# Altium schematic sheet MAX31856 Sensors 1.SchDoc
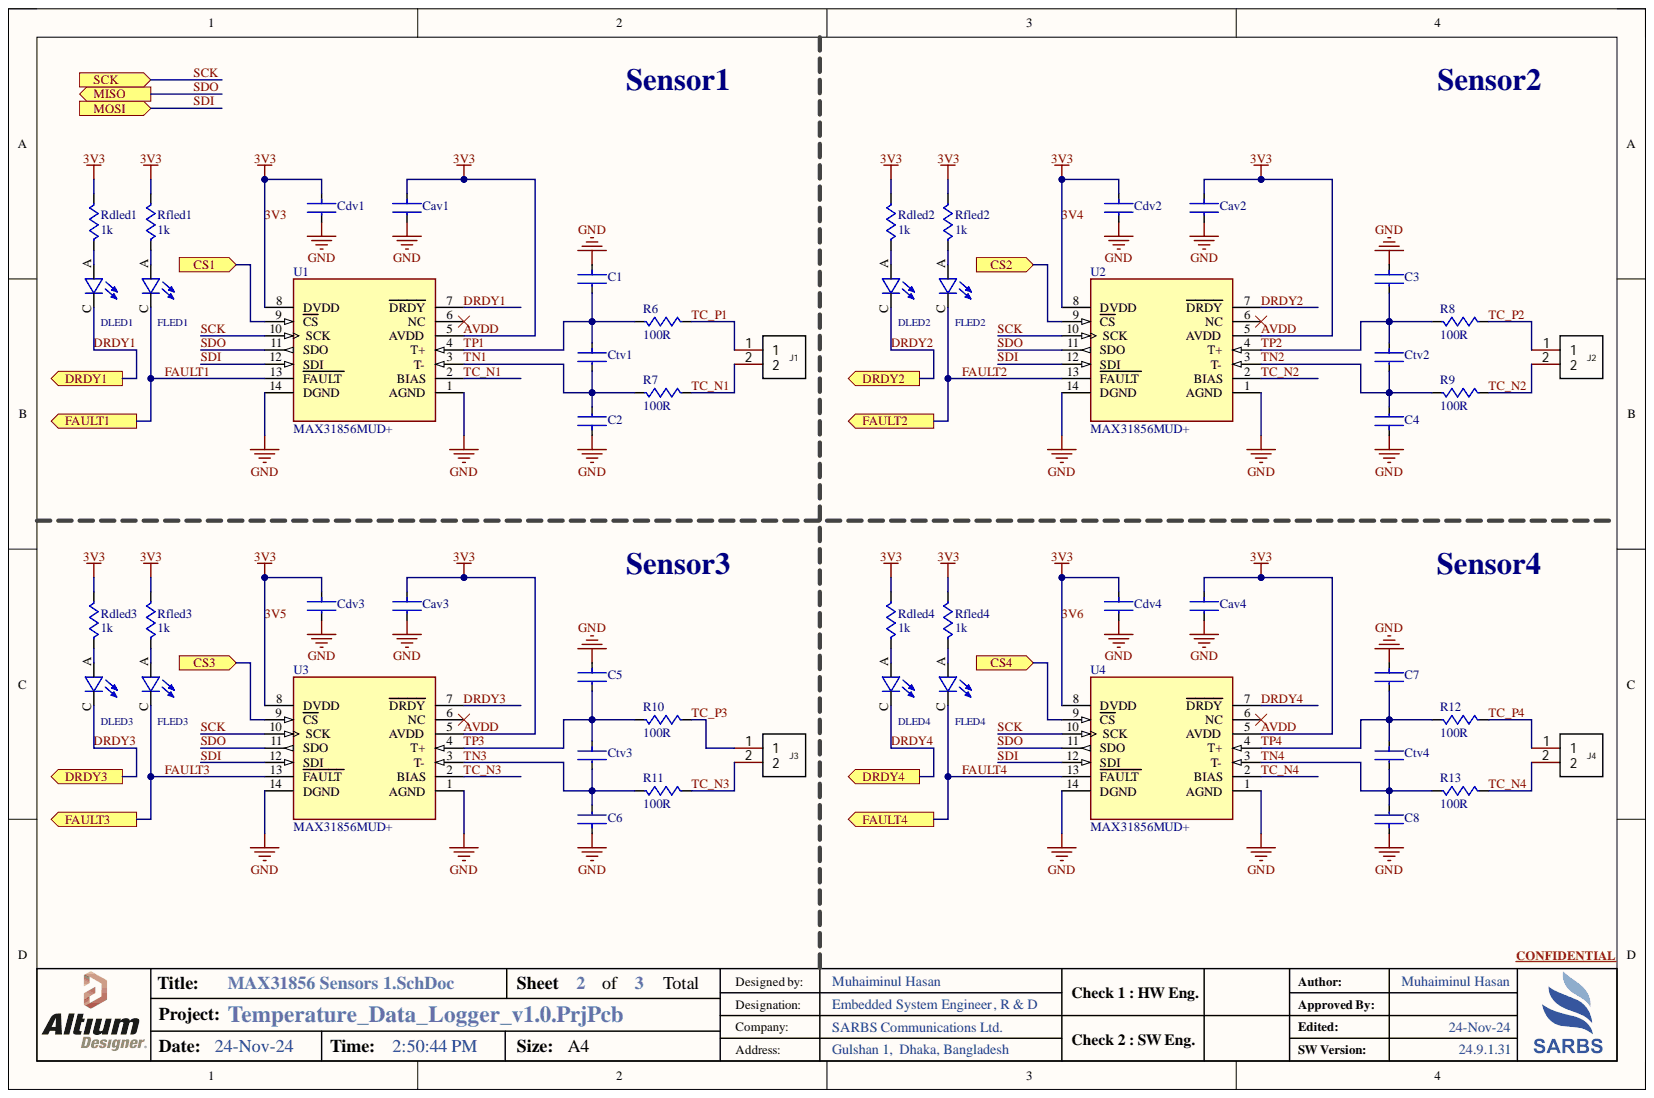
[diagram p0: MAX31856 Sensors 1.SchDoc]

## Parts
C1  (no value)  [0,1uF 25V cap TH CNC]
C2  (no value)  [0,1uF 25V cap TH CNC]
C3  (no value)  [0,1uF 25V cap TH CNC]
C4  (no value)  [0,1uF 25V cap TH CNC]
C5  (no value)  [0,1uF 25V cap TH CNC]
C6  (no value)  [0,1uF 25V cap TH CNC]
C7  (no value)  [0,1uF 25V cap TH CNC]
C8  (no value)  [0,1uF 25V cap TH CNC]
Cav1  (no value)  [0,1uF 25V cap TH CNC]
Cav2  (no value)  [0,1uF 25V cap TH CNC]
Cav3  (no value)  [0,1uF 25V cap TH CNC]
Cav4  (no value)  [0,1uF 25V cap TH CNC]
Cdv1  (no value)  [0,1uF 25V cap TH CNC]
Cdv2  (no value)  [0,1uF 25V cap TH CNC]
Cdv3  (no value)  [0,1uF 25V cap TH CNC]
Cdv4  (no value)  [0,1uF 25V cap TH CNC]
Ctv1  (no value)  [0,1uF 25V cap TH CNC]
Ctv2  (no value)  [0,1uF 25V cap TH CNC]
Ctv3  (no value)  [0,1uF 25V cap TH CNC]
Ctv4  (no value)  [0,1uF 25V cap TH CNC]
DLED1  L08R5000Q1  [LEDRD254W57D500H1070]  (value not drawn)
DLED2  L08R5000Q1  [LEDRD254W57D500H1070]  (value not drawn)
DLED3  L08R5000Q1  [LEDRD254W57D500H1070]  (value not drawn)
DLED4  L08R5000Q1  [LEDRD254W57D500H1070]  (value not drawn)
FLED1  L08R5000Q1  [LEDRD254W57D500H1070]  (value not drawn)
FLED2  L08R5000Q1  [LEDRD254W57D500H1070]  (value not drawn)
FLED3  L08R5000Q1  [LEDRD254W57D500H1070]  (value not drawn)
FLED4  L08R5000Q1  [LEDRD254W57D500H1070]  (value not drawn)
J1  WJ2EDGRC-5.08-2P  [Terminal Block P5.08-2P]  (value not drawn)
J2  WJ2EDGRC-5.08-2P  [Terminal Block P5.08-2P]  (value not drawn)
J3  WJ2EDGRC-5.08-2P  [Terminal Block P5.08-2P]  (value not drawn)
J4  WJ2EDGRC-5.08-2P  [Terminal Block P5.08-2P]  (value not drawn)
R6  100R  [RESA60-630X240]
R7  100R  [RESA60-630X240]
R8  100R  [RESA60-630X240]
R9  100R  [RESA60-630X240]
R10  100R  [RESA60-630X240]
R11  100R  [RESA60-630X240]
R12  100R  [RESA60-630X240]
R13  100R  [RESA60-630X240]
Rdled1  1k  [RESA60-630X240]
Rdled2  1k  [RESA60-630X240]
Rdled3  1k  [RESA60-630X240]
Rdled4  1k  [RESA60-630X240]
Rfled1  1k  [RESA60-630X240]
Rfled2  1k  [RESA60-630X240]
Rfled3  1k  [RESA60-630X240]
Rfled4  1k  [RESA60-630X240]
U1  MAX31856MUD+  [FP-U14-2-MFG]
U2  MAX31856MUD+  [FP-U14-2-MFG]
U3  MAX31856MUD+  [FP-U14-2-MFG]
U4  MAX31856MUD+  [FP-U14-2-MFG]

## Ports
CS1, CS2, CS3, CS4, DRDY1, DRDY2, DRDY3, DRDY4, FAULT1, FAULT2, FAULT3, FAULT4, MISO, MOSI, SCK

## Nets
GND: C1.1 C2.1 C3.1 C4.1 C5.1 C6.1 C7.1 C8.1 Cav1.2 Cav2.2 Cav3.2 Cav4.2 Cdv1.2 Cdv2.2 Cdv3.2 Cdv4.2 U1.1(AGND) U1.14(DGND) U2.1(AGND) U2.14(DGND) U3.1(AGND) U3.14(DGND) U4.1(AGND) U4.14(DGND)
3V3: Cav1.1 Cav2.1 Cav3.1 Cav4.1 Cdv1.1 Cdv2.1 Cdv3.1 Cdv4.1 Rdled1.2 Rdled2.2 Rdled3.2 Rdled4.2 Rfled1.2 Rfled2.2 Rfled3.2 Rfled4.2 U1.5(AVDD) U1.8(DVDD) U2.5(AVDD) U2.8(DVDD) U3.5(AVDD) U3.8(DVDD) U4.5(AVDD) U4.8(DVDD)
CS1: U1.9(CS) ; port CS1
CS2: U2.9(CS) ; port CS2
CS3: U3.9(CS) ; port CS3
CS4: U4.9(CS) ; port CS4
DRDY1: DLED1.C U1.7(DRDY) ; port DRDY1
DRDY2: DLED2.C U2.7(DRDY) ; port DRDY2
DRDY3: DLED3.C U3.7(DRDY) ; port DRDY3
DRDY4: DLED4.C U4.7(DRDY) ; port DRDY4
FAULT1: FLED1.C U1.13(FAULT) ; port FAULT1
FAULT2: FLED2.C U2.13(FAULT) ; port FAULT2
FAULT3: FLED3.C U3.13(FAULT) ; port FAULT3
FAULT4: FLED4.C U4.13(FAULT) ; port FAULT4
SCK: U1.10(SCK) U2.10(SCK) U3.10(SCK) U4.10(SCK) ; port SCK
SDI: U1.12(SDI) U2.12(SDI) U3.12(SDI) U4.12(SDI) ; port MOSI
SDO: U1.11(SDO) U2.11(SDO) U3.11(SDO) U4.11(SDO) ; port MISO
TC_N1: J1.2 R7.1 U1.2(BIAS)
TC_N2: J2.2 R9.1 U2.2(BIAS)
TC_N3: J3.2 R11.1 U3.2(BIAS)
TC_N4: J4.2 R13.1 U4.2(BIAS)
TC_P1: J1.1 R6.1
TC_P2: J2.1 R8.1
TC_P3: J3.1 R10.1
TC_P4: J4.1 R12.1
TN1: C2.2 Ctv1.2 R7.2 U1.3(T-)
TN2: C4.2 Ctv2.2 R9.2 U2.3(T-)
TN3: C6.2 Ctv3.2 R11.2 U3.3(t)
TN4: C8.2 Ctv4.2 R13.2 U4.3(T-)
TP1: C1.2 Ctv1.1 R6.2 U1.4(T+)
TP2: C3.2 Ctv2.1 R8.2 U2.4(T+)
TP3: C5.2 Ctv3.1 R10.2 U3.4(T+)
TP4: C7.2 Ctv4.1 R12.2 U4.4(T+)
NetDLED1_A: DLED1.A Rdled1.1
NetDLED2_A: DLED2.A Rdled2.1
NetDLED3_A: DLED3.A Rdled3.1
NetDLED4_A: DLED4.A Rdled4.1
NetFLED1_A: FLED1.A Rfled1.1
NetFLED2_A: FLED2.A Rfled2.1
NetFLED3_A: FLED3.A Rfled3.1
NetFLED4_A: FLED4.A Rfled4.1

Not connected: U1 6(NC); U2 6(NC); U3 6(NC); U4 6(NC)

## Library data of the parts
C1-C8 Cav1-Cav4 Cdv1-Cdv4 Ctv1-Ctv4 = CMP-06035-046728-1: Ceramic Capacitor 100nF ±10% 25V X7R Radial; Lead Diameter: 0.5mm; Capacitance: 100nF; Case/Package: Radial; Component Kind: Standard; Dielectric: X7R; Height: 3.5mm; Height - Seated (Max): 0.236inch; Lead Free: Lead Free; Lead Pitch: 0.098inch; Length: 0.197inch; Library Name: 0.1uF 25V cap cer TH.SchLib; Max Operating Temperature: 125°C; Min Operating Temperature: -55°C; Mount: Through Hole; Package Quantity: 2000; Packaging: Tape and Reel; Resistor Type: Ceramic; Termination: Radial; Tolerance: 10%; Voltage Rating: 25V; Voltage Rating (DC): 25V; Width: 3.5mm
DLED1-DLED4 FLED1-FLED4 = L08R5000Q1: LED, 5MM, ORANGE; LED / Lamp Size: 5mm / T-1 3/4; LED Colour: Orange; Typ Luminous Intensity: 4.3mcd; Viewing Angle: ...; MF: LED Technology; Availability: In Stock; Check_prices: https://www.snapeda.com/parts/L08R5000Q1/LED+Technology/view-part/?ref=eda; Component Kind: Standard; Library Name: LED 3mm.SchLib; MP: L08R5000Q1; Package: None; Price: None; SnapEDA_Link: https://www.snapeda.com/parts/L08R5000Q1/LED+Technology/view-part/?ref=snap
J1-J4 = WJ2EDGRC-5.08-2P: Manufacturer: KANGNEX(康奈克斯电气); Add into BOM: yes; Connector Type: 板端/Receptacle-Close; Number of PINs Per Row: 2; Pitch: 5.08mm; Structure: 1x2P; Color: Green; Component Kind: Standard; Convert to PCB: yes; Datasheet: https://atta.szlcsc.com/upload/public/pdf/source/20191225/C3697_6E7B45CD3FD3DA2CDF6A2CE707235E10.pdf; Device: WJ2EDGRC-5.08-2P; JLCPCB Part Class: Extended Part; LCSC Part Name: 5.08mm 1x2P 排数:1 每排P数:2; Library Name: Terminal Block P5.08-2P.SchLib; Manufacturer Part: WJ2EDGRC-5.08-2P; Mounting Style: Bend; Number of Pins: 2P; Number of Rows: 1; Supplier: LCSC; Supplier Footprint: 弯插,P=5.08mm; Supplier Part: C3697; Symbol: WJ2EDGRC-5.08-2P
R6-R13 Rdled1-Rdled4 Rfled1-Rfled4 = CMP-1659-00075-1: Axial Resistor,; Power Rating: 250 mW; Resistance: 1 kohm; Tolerance: 1%; Voltage Rating: 250 V; Case\Package: Axial; Component Kind: Standard; ComponentLink1Description: Manufacturer URL; ComponentLink1URL: http://www.yageo.com/; ComponentLink2Description: Datasheet; ComponentLink2URL: http://www.yageo.com/documents/recent/Yageo%20LR_MFR_2013.pdf; DatasheetVersion: Apr-13; Length: 0.248 inch; Library Name: Resistor Generic.SchLib; Max Operating Temperature: 155 degC; Min Operating Temperature: -55 degC; Package Quantity: 200; PackageDescription: 2-Pin Axial Lead, Dia 2.4 mm, Body Length 6.3 mm; PackageReference: MFR-25; Packaging: Bulk; RoHSCompliant: true
U1-U4 = CMP-05752-000010-1: IC CONV THERMOCOUPLE 14TSSOP; Interface: SPI; Max Supply Voltage: 3.6V; Min Supply Voltage: 3V; Operating Supply Current: 1.2mA; Output Type: Digital; Case/Package: TSSOP; Component Kind: Standard; Library Name: MAX31856MUD.SchLib; Max Operating Temperature: 125°C; Min Operating Temperature: -55°C; Mount: Surface Mount; Number of Pins: 14; Packaging: Rail/Tube; Supplier 1: Mouser; Supplier 2: Digikey; Supplier 3: Arrow Electronics; Supplier 4: Newark; Supplier 5: Farnell; Supplier Part Number 1: 700-MAX31856MUD+; Supplier Part Number 2: MAX31856MUD+-ND; Supplier Part Number 3: MAX31856MUD+; Supplier Part Number 4: 73Y3359; Supplier Part Number 5: 2515571
